# Altium schematic sheet MCU.SchDoc
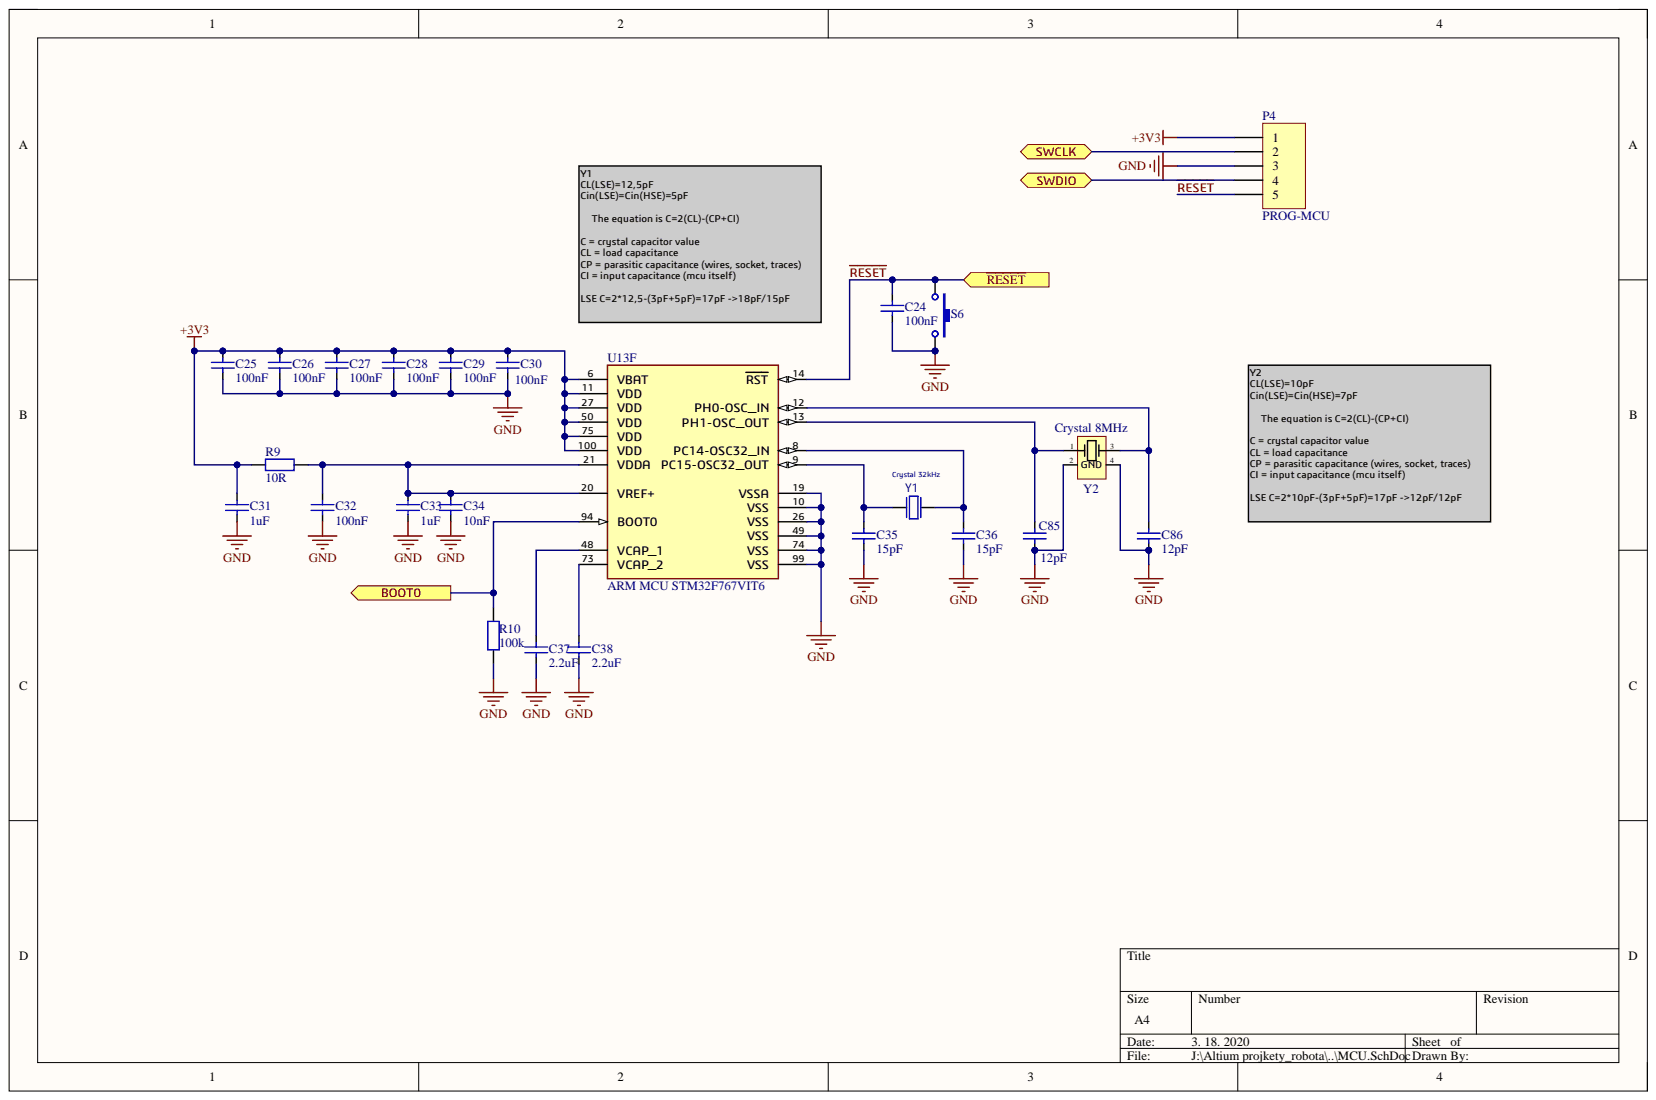
[diagram p0: MCU.SchDoc]

## Parts
C24  100nF  [CAPACITOR_0402_(1005)]
C25  100nF  [CAPACITOR_0402_(1005)]
C26  100nF  [CAPACITOR_0402_(1005)]
C27  100nF  [CAPACITOR_0402_(1005)]
C28  100nF  [CAPACITOR_0402_(1005)]
C29  100nF  [CAPACITOR_0402_(1005)]
C30  100nF  [CAPACITOR_0402_(1005)]
C31  1uF  [CAPACITOR_0603_(1608)]
C32  100nF  [CAPACITOR_0402_(1005)]
C33  1uF  [CAPACITOR_0603_(1608)]
C34  10nF  [CAPACITOR_0402_(1005)]
C35  15pF  [CAPACITOR_0402_(1005)]
C36  15pF  [CAPACITOR_0402_(1005)]
C37  2.2uF  [CAPACITOR_0603_(1608)]
C38  2.2uF  [CAPACITOR_0603_(1608)]
C85  12pF  [CAPACITOR_0402_(1005)]
C86  12pF  [CAPACITOR_0402_(1005)]
P4  PROG-MCU  [HDR1X5]
R9  10R  [RESISTOR_0603_(1608)]
R10  100k  [RESISTOR_0603_(1608)]
S6  DTSM-32S-B -  Tactile Switch  [DTSM-32S-B]  (value not drawn)
U13  ARM MCU STM32F767VIT6  Manufacturer Part Number: STM32F767VIT6  [STM-LQFP100_N]  (part F)
Y1  Crystal 32kHz  [ABS07]
Y2  Crystal 8MHz  Frequency: 8MHz  [SMD Crystal 5.0 X 3.2 X 1.1mm]

## Ports
BOOT0, SWCLK, SWDIO, ~{RESET}

## Nets
+3V3: C25.1 C26.1 C27.1 C28.1 C29.1 C30.1 C31.1 P4.1 R9.1 U13.6(VBAT) U13.11(VDD) U13.27(VDD) U13.50(VDD) U13.75(VDD) U13.100(VDD)
GND: C24.2 C25.2 C26.2 C27.2 C28.2 C29.2 C30.2 C31.2 C32.2 C33.2 C34.2 C35.1 C36.1 C37.1 C38.1 C85.1 C86.1 P4.3 R10.1 S6.1 U13.10(VSS) U13.19(VSSA) U13.26(VSS) U13.49(VSS) U13.74(VSS) U13.99(VSS) Y2.2 Y2.4(GND)
BOOT0: R10.2 U13.94(BOOT0) ; port BOOT0
~{RESET}: C24.1 P4.5 S6.2 U13.14(RST) ; port ~{RESET}
SWCLK: P4.2 ; port SWCLK
SWDIO: P4.4 ; port SWDIO
NetC32_1: C32.1 C33.1 C34.1 R9.2 U13.20(VREF+) U13.21(VDDA)
NetC35_2: C35.2 U13.9(PC15-OSC32_OUT) Y1.2
NetC36_2: C36.2 U13.8(PC14-OSC32_IN) Y1.1
NetC37_2: C37.2 U13.48(VCAP_1)
NetC38_2: C38.2 U13.73(VCAP_2)
NetC85_2: C85.2 U13.13(PH1-OSC_OUT) Y2.1
NetC86_2: C86.2 U13.12(PH0-OSC_IN) Y2.3

## Library data of the parts
C24-C36 C85 C86 = CAPACITOR: ESR: 0.28R; Voltage Rating: 10V; Component Kind: Standard; Description: CAPACITOR; Library Name: RLCFB.SchLib
  C24-C30 C32: Capacitance: 100nF
  C31 C33: Capacitance: 1uF
  C34: Capacitance: 10nF
  C35 C36: Capacitance: 15pF
  C85 C86: Capacitance: 12pF
C37 C38 = CAPACITOR: Manufacturer 1: Murata; Manufacturer Part Number 1: GRT188R61H225KE13D; Dielectric: X5R; Tolerance: 10%; Voltage Rating: 50V; Capacitance: 2.2uF; Case Code (Imperial): 0603; Case Code (Metric): 1608; Case/Package: 0603; Component Kind: Standard; ComponentLink1Description: http://www.farnell.com/datasheets/2165960.pdf?src-supplier=Farnell; ComponentLink1URL: http://www.farnell.com/datasheets/2165960.pdf?src-supplier=Farnell; ComponentLink2Description: http://search.murata.co.jp/Ceramy/image/img/A01X/G101/ENG/GRT188R61H225KE13-01.pdf?src-supplier=Digi-Key; ComponentLink2URL: http://search.murata.co.jp/Ceramy/image/img/A01X/G101/ENG/GRT188R61H225KE13-01.pdf?src-supplier=Digi-Key; Description: CAPACITOR; Length: 0.063inch; Max Operating Temperature: 85°C; Min Operating Temperature: -55°C; Mount: Surface Mount; Packaging: Tape and Reel; Pricing 1: 10=0,29600, 100=0,13900, 500=0,09800, 1000=0,08370, 2000=0,08180, 4000=0,05810, 20000=0,05620 (EUR); Ratings: AEC-Q200; RoHS: Yes; Stock 1: 31469; Supplier 1: Farnell; Supplier Part Number 1: 2672161; Thickness: 0.035inch; Voltage Rating (DC): 50V; Width: 0.031inch; Library Name: RLCFB.SchLib
P4 = Header 5: Header, 5-Pin; Component Kind: Standard; LatestRevisionDate: 17-Jul-2002; LatestRevisionNote: Re-released for DXP Platform.; Library Name: Miscellaneous Connectors.IntLib; Signal Integrity: Connector; Publisher: Altium Limited
R9 R10 = RESISTOR: Power Rating: 500mW; Component Kind: Standard; Description: RESISTOR; Library Name: RLCFB.SchLib
  R9: Resistance: 10R
  R10: Resistance: 100k
S6 = Switch tactile: DTSM-32S-B -  Tactile Switch, DTSM-3 Series, Top Actuated, Surface Mount, Rectangular Button, 320 gf; Manufacturer 1: Multicomp; Contact Resistance: 100mR; Current Rating: 50mA; Illumination Type: Illuminated; Operating Force: 3.14N; Throw Configuration: SPST; Voltage Rating (DC): 12V; Case/Package: SMD/SMT; Component Kind: Standard; ComponentLink1Description: https://datasheet.ciiva.com/1532/44707-1532733.pdf?src-supplier=Element14; ComponentLink1URL: https://datasheet.ciiva.com/1532/44707-1532733.pdf?src-supplier=Element14; ComponentLink2Description: https://datasheet.ciiva.com/1532/10424-1532734.pdf?src-supplier=Element14; ComponentLink2URL: https://datasheet.ciiva.com/1532/10424-1532734.pdf?src-supplier=Element14; ComponentLink3Description: https://datasheet.ciiva.com/22631/1662013-22631920.pdf?src-supplier=Farnell; ComponentLink3URL: https://datasheet.ciiva.com/22631/1662013-22631920.pdf?src-supplier=Farnell; Height: 5mm; Insulation Resistance: 100MR; Length: 6mm; Library Name: BB1_SCH_Library.SchLib; Manufacturer Part Number 1: DTSM-32S-B; Max Operating Temperature: 70°C; Min Operating Temperature: -25°C; Pricing 1: 15=0,18700, 150=0,15100, 500=0,10800, 1000=0,09630, 1500=0,08590, 5000=0,07220 (EUR); REACH SVHC: No SVHC; RoHS: Yes; Stock 1: 170226; Supplier 1: Farnell; Supplier Part Number 1: 9471898; Weight: 0.01lb; Width: 6mm
U13 = STM32F767VIT6_LQFP100: STM32F767VIT6 -  ARM MCU, ART Accelerator, STM32 Family STM32F7 Series Microcontrollers, ARM Cortex-M7, 32bit; Manufacturer: STMicroelectronics; Frequency: 216MHz; Max Supply Voltage: 3.6V; Memory Size: 2MB; Min Supply Voltage: 1.7V; Number of I/Os: 82; RAM Size: 512KB; Case/Package: LQFP; Component Kind: Standard; ComponentLink1Description: http://farnell.com//datasheets/2282439.pdf?src-supplier=Farnell; ComponentLink1URL: http://farnell.com//datasheets/2282439.pdf?src-supplier=Farnell; ComponentLink2Description: http://www.farnell.com/datasheets/2034586.pdf?src-supplier=Element14; ComponentLink2URL: http://www.farnell.com/datasheets/2034586.pdf?src-supplier=Element14; ComponentLink3Description: http://www.st.com/content/ccc/resource/technical/document/datasheet/group3/c5/37/9c/1d/a6/09/4e/1a/DM00273119/files/DM00273119.pdf/jcr:content/translations/en.DM00273119.pdf?src-supplier=Digi-Key; ComponentLink3URL: http://www.st.com/content/ccc/resource/technical/document/datasheet/group3/c5/37/9c/1d/a6/09/4e/1a/DM00273119/files/DM00273119.pdf/jcr:content/translations/en.DM00273119.pdf?src-supplier=Digi-Key; Library Name: BB1_SCH_Library.SchLib; Number of Pins: 100; Pricing 1: 1=14,27000, 10=12,66000, 25=12,13000, 50=11,15000, 100=10,17000 (EUR); REACH SVHC: No SVHC; RoHS: No; Stock 1: 313; Supplier 1: Farnell; Supplier Part Number 1: 2535500
Y1 = xtal 32kHz: ABRACON - ABS07-32.768KHZ-T - CRYSTAL, 32.768KHZ, 12.5PF, SMD; Component Kind: Standard; ComponentLink1URL: http://www.farnell.com/datasheets/1700986.pdf; Library Name: BB1_SCH_Library.SchLib; Manufacturer: ABRACON; Manufacturer Part Number: ABS07-32.768KHZ-T; Supplier 1: Farnell; Supplier Part Number 1: 2101347
Y2 = Crystal ABM3B 8MHz: CRYSTAL 8.0000MHZ 10PF SMD; Manufacturer 1: Abracon; Manufacturer Part Number 1: ABM3B-8.000MHZ-10-1-U-T; ESR (Equivalent Series Resistance): 200R; Frequency Stability: 10ppm; Case/Package: SMD/SMT; Category: Crystals; Component Kind: Standard; ComponentLink1Description: https://datasheet.ciiva.com/855/abm3b-855873.pdf?src-supplier=Digi-Key; ComponentLink1URL: https://datasheet.ciiva.com/855/abm3b-855873.pdf?src-supplier=Digi-Key; ComponentLink2Description: https://datasheet.ciiva.com/855/abm3b-855874.pdf?src-supplier=Digi-Key; ComponentLink2URL: https://datasheet.ciiva.com/855/abm3b-855874.pdf?src-supplier=Digi-Key; ComponentLink3Description: https://datasheet.ciiva.com/855/abm3b-855875.pdf?src-supplier=Digi-Key; ComponentLink3URL: https://datasheet.ciiva.com/855/abm3b-855875.pdf?src-supplier=Digi-Key; ComponentLink4Description: https://datasheet.ciiva.com/16378/abm3b-16378027.pdf?src-supplier=Future+Electronics; ComponentLink4URL: https://datasheet.ciiva.com/16378/abm3b-16378027.pdf?src-supplier=Future+Electronics; ComponentLink5Description: https://datasheet.ciiva.com/3175/abm3b-3175853.pdf?src-supplier=Avnet; ComponentLink5URL: https://datasheet.ciiva.com/3175/abm3b-3175853.pdf?src-supplier=Avnet; Depth: 3.2mm; Frequency Tolerance: 10ppm; Height: 0.043inch; Lead Free: Lead Free; Length: 0.197inch; Library Name: BB1_SCH_Library.SchLib; Load Capacitance: 10pF; Max Operating Temperature: 60°C; Min Operating Temperature: -10°C; Mount: Surface Mount; Number of Pins: 4; Packaging: Tape and Reel; Pricing 1: 1=0,69000, 10=0,57800, 50=0,51900, 100=0,46200, 500=0,43800, 1000=0,43800 (USD); REACH SVHC: No SVHC; RoHS: Yes; Series Resistance: 200R; Stock 1: 9293; Supplier 1: Mouser; Supplier Part Number 1: 815-ABM3B8MHZ101UT; Width: 0.126inch
